# Altium schematic sheet Interface.SchDoc
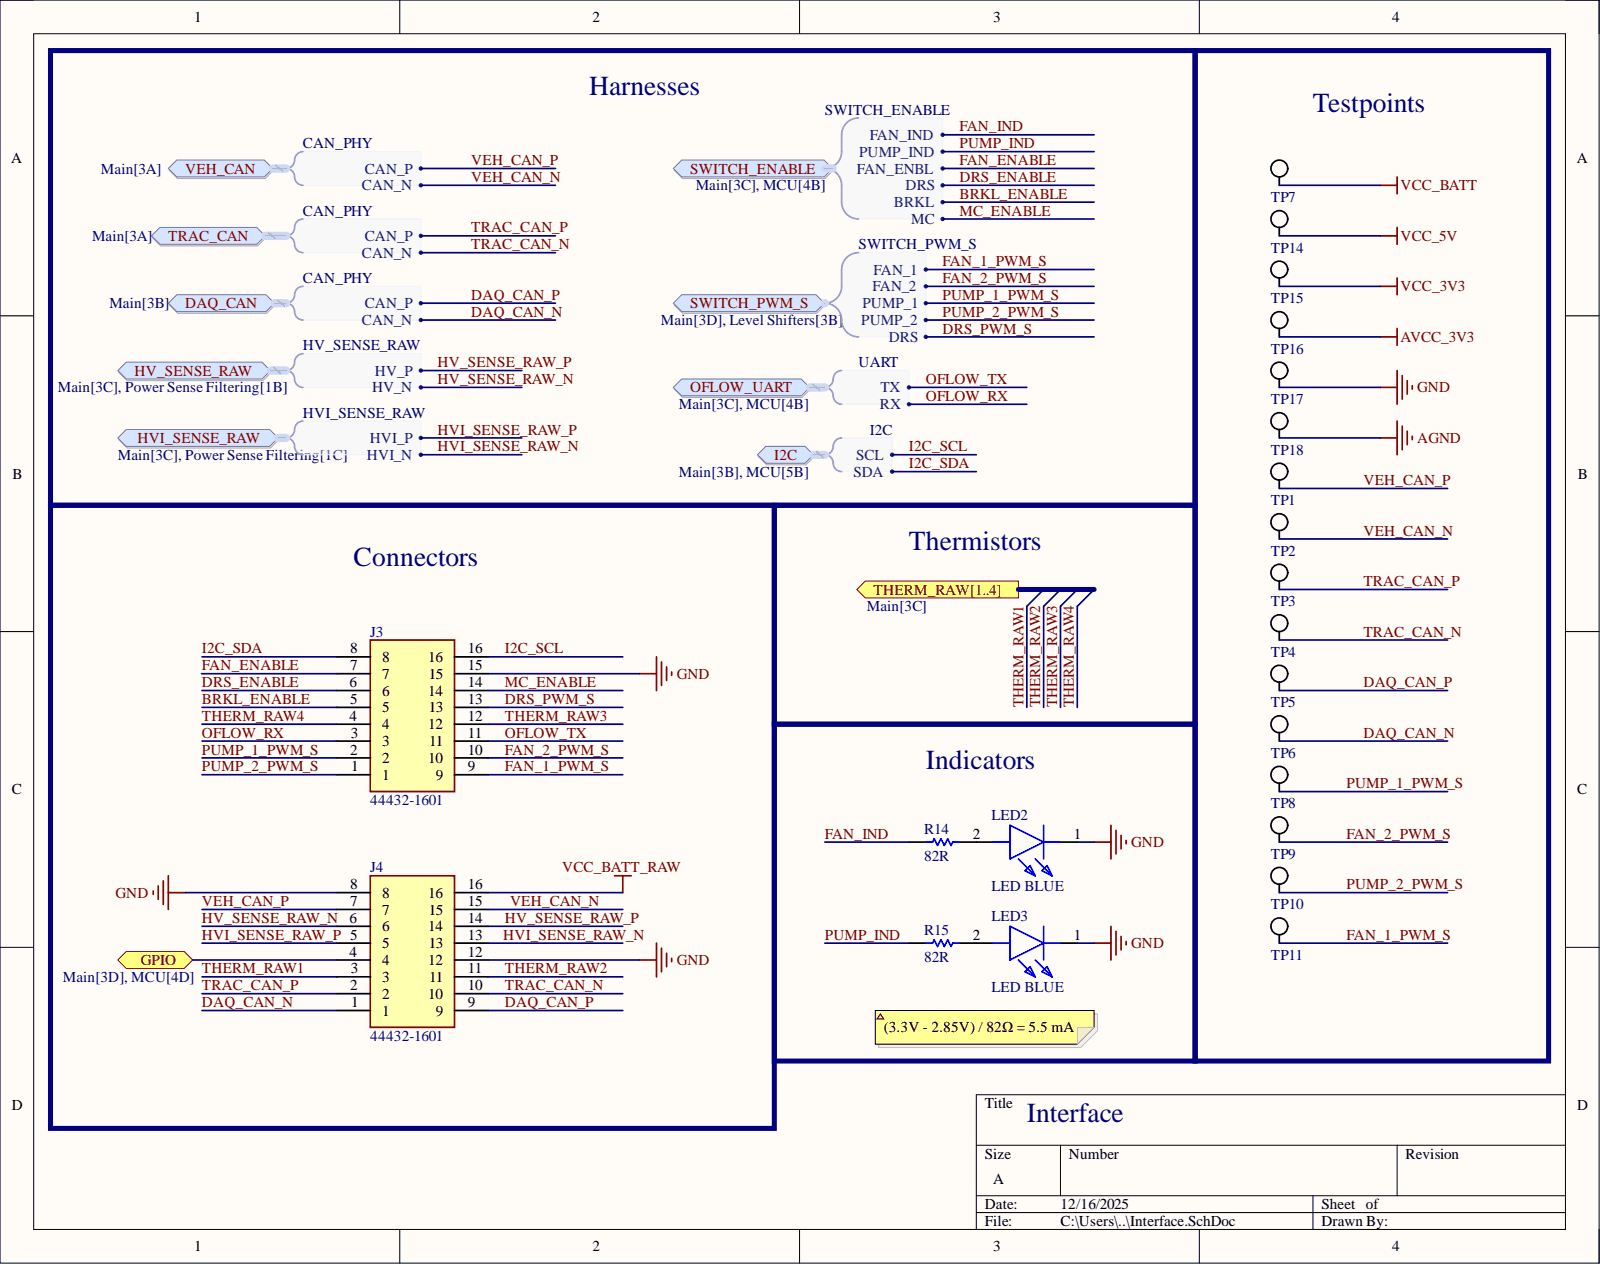
[diagram p0: Interface.SchDoc]

## Parts
J3  44432-1601  [44432-16(01,02,03)]
J4  44432-1601  [44432-16(01,02,03)]
LED2  LED BLUE  [LBQ99G01P1R1351]
LED3  LED BLUE  [LBQ99G01P1R1351]
R14  82R  [RES_SM0603_YAGEORC_N]
R15  82R  [RES_SM0603_YAGEORC_N]
TP1  Test Point Loop  [Test Point Loop - Black]  (value not drawn)
TP2  Test Point Loop  [Test Point Loop - Black]  (value not drawn)
TP3  Test Point Loop  [Test Point Loop - Black]  (value not drawn)
TP4  Test Point Loop  [Test Point Loop - Black]  (value not drawn)
TP5  Test Point Loop  [Test Point Loop - Black]  (value not drawn)
TP6  Test Point Loop  [Test Point Loop - Black]  (value not drawn)
TP7  Test Point Loop  [Test Point Loop - Black]  (value not drawn)
TP8  Test Point Loop  [Test Point Loop - Black]  (value not drawn)
TP9  Test Point Loop  [Test Point Loop - Black]  (value not drawn)
TP10  Test Point Loop  [Test Point Loop - Black]  (value not drawn)
TP11  Test Point Loop  [Test Point Loop - Black]  (value not drawn)
TP14  Test Point Loop  [Test Point Loop - Black]  (value not drawn)
TP15  Test Point Loop  [Test Point Loop - Black]  (value not drawn)
TP16  Test Point Loop  [Test Point Loop - Black]  (value not drawn)
TP17  Test Point Loop  [Test Point Loop - Black]  (value not drawn)
TP18  Test Point Loop  [Test Point Loop - Black]  (value not drawn)

## Ports
DAQ_CAN, DAQ_CAN.CAN_N, DAQ_CAN.CAN_P, GPIO, HV_SENSE_RAW, HV_SENSE_RAW.HV_N, HV_SENSE_RAW.HV_P, HVI_SENSE_RAW, HVI_SENSE_RAW.HVI_N, HVI_SENSE_RAW.HVI_P, I2C, I2C.SCL, I2C.SDA, OFLOW_UART, OFLOW_UART.RX, OFLOW_UART.TX, SWITCH_ENABLE, SWITCH_ENABLE.BRKL, SWITCH_ENABLE.DRS, SWITCH_ENABLE.FAN_ENBL, SWITCH_ENABLE.FAN_IND, SWITCH_ENABLE.MC, SWITCH_ENABLE.PUMP_IND, SWITCH_PWM_S, SWITCH_PWM_S.DRS, SWITCH_PWM_S.FAN_1, SWITCH_PWM_S.FAN_2, SWITCH_PWM_S.PUMP_1, SWITCH_PWM_S.PUMP_2, THERM_RAW1, THERM_RAW2, THERM_RAW3, THERM_RAW4, THERM_RAW[1..4], TRAC_CAN, TRAC_CAN.CAN_N, TRAC_CAN.CAN_P, VEH_CAN, VEH_CAN.CAN_N, VEH_CAN.CAN_P

## Nets
AGND: TP18.1
AVCC_3V3: TP16.1
GND: J3.15 J4.8 J4.12 LED2.1 LED3.1 TP17.1
VCC_3V3: TP15.1
VCC_5V: TP14.1
VCC_BATT: TP7.1
VCC_BATT_RAW: J4.16
BRKL_ENABLE: J3.5 ; port SWITCH_ENABLE
DAQ_CAN_N: J4.1 TP6.1 ; port DAQ_CAN
DAQ_CAN_P: J4.9 TP5.1 ; port DAQ_CAN
DRS_ENABLE: J3.6 ; port SWITCH_ENABLE
DRS_PWM_S: J3.13 ; port SWITCH_PWM_S
FAN_1_PWM_S: J3.9 TP11.1 ; port SWITCH_PWM_S
FAN_2_PWM_S: J3.10 TP9.1 ; port SWITCH_PWM_S
FAN_ENABLE: J3.7 ; port SWITCH_ENABLE
FAN_IND: R14.1 ; port SWITCH_ENABLE
GPIO: J4.4 ; port GPIO
HV_SENSE_RAW_N: J4.6 ; port HV_SENSE_RAW
HV_SENSE_RAW_P: J4.14 ; port HV_SENSE_RAW
HVI_SENSE_RAW_N: J4.13 ; port HVI_SENSE_RAW
HVI_SENSE_RAW_P: J4.5 ; port HVI_SENSE_RAW
I2C_SCL: J3.16 ; port I2C
I2C_SDA: J3.8 ; port I2C
MC_ENABLE: J3.14 ; port SWITCH_ENABLE
OFLOW_RX: J3.3 ; port OFLOW_UART
OFLOW_TX: J3.11 ; port OFLOW_UART
PUMP_1_PWM_S: J3.2 TP8.1 ; port SWITCH_PWM_S
PUMP_2_PWM_S: J3.1 TP10.1 ; port SWITCH_PWM_S
PUMP_IND: R15.1 ; port SWITCH_ENABLE
THERM_RAW1: J4.3 ; port THERM_RAW[1..4]  [also labelled ]
THERM_RAW2: J4.11 ; port THERM_RAW[1..4]  [also labelled ]
THERM_RAW3: J3.12 ; port THERM_RAW[1..4]  [also labelled ]
THERM_RAW4: J3.4 ; port THERM_RAW[1..4]  [also labelled ]
TRAC_CAN_N: J4.10 TP4.1 ; port TRAC_CAN
TRAC_CAN_P: J4.2 TP3.1 ; port TRAC_CAN
VEH_CAN_N: J4.15 TP2.1 ; port VEH_CAN
VEH_CAN_P: J4.7 TP1.1 ; port VEH_CAN
NetLED2_2: LED2.2 R14.2
NetLED3_2: LED3.2 R15.2

## Library data of the parts
J3 J4 = 44432-1601: 16 pin 8x2 molex; Component Kind: Standard; Datasheet Link: https://www.molex.com/pdm_docs/sd/444320401_sd.pdf; Height: 11.6mm; Library Name: Connectors.IntLib; Manufacturer_Name: Molex; Manufacturer_Part_Number: 44432-1601; Mouser Part Number: 538-44432-1601; Mouser Price/Stock: https://www.mouser.co.uk/ProductDetail/Molex/44432-1601?qs=636lKt2SogOef5xSI9yrqg%3D%3D
  J3: Supplier 1: DigiKey; Supplier Part Number 1: 23-0444321601-ND
LED2 LED3 = LB Q99G.01-P1R1-35-1-5-R18: LED Blue 2.85V 5mA USE THIS ONE; Manufacturer_Name: ams OSRAM; Component Kind: Standard; Datasheet Link: https://look.ams-osram.com/m/5d39d28026a1abba/original/LB-Q99G-01.pdf; Height: 0.75mm; Library Name: DiodesAndFuses.IntLib; Manufacturer_Part_Number: LB Q99G.01-P1R1-35-1; Mouser Part Number: 720-LBQ99G01P1R135; Mouser Price/Stock: https://www.mouser.co.uk/ProductDetail/ams-OSRAM/LB-Q99G.01-P1R1-35-1?qs=IPgv5n7u5QZHWhEkNg3xgA%3D%3D
R14 R15 = RC0603FR-0782RL: RES 82R SM0603 YAGEO RC_L; Power (Watts): 0.1; Resistance (Ohms): 82; Tolerance (%): 1; Category: Resistor; Component Kind: Standard; ComponentLink1Description: Manufacturer Website; ComponentLink1URL: http://www.yageo.com; Library Name: ResistorCapsInductors.IntLib; Manufacturer: Yageo; Manufacturer Part Number: RC0603FR-0782RL; Package: 0603; Supplier 1: Farnell; Supplier 2: Digi-Key; Supplier Part Number 1: 9238352; Supplier Part Number 2: 311-82.0HRCT-ND; Value: 82R
TP1-TP11 TP14-TP18 = Test Point Loop: Keyston Electronics 5001 Test Point Loop; Manufacturer: Keystone Electronics; Manufacturer P/N: 5001; Color: Black; Component Kind: Standard; Library Name: Misc.IntLib; Supplier 1: Digi-Key; Supplier Part Number 1: 36-5001-ND
